# Altium schematic sheet MAIN-POWERSUPPLY.SchDoc
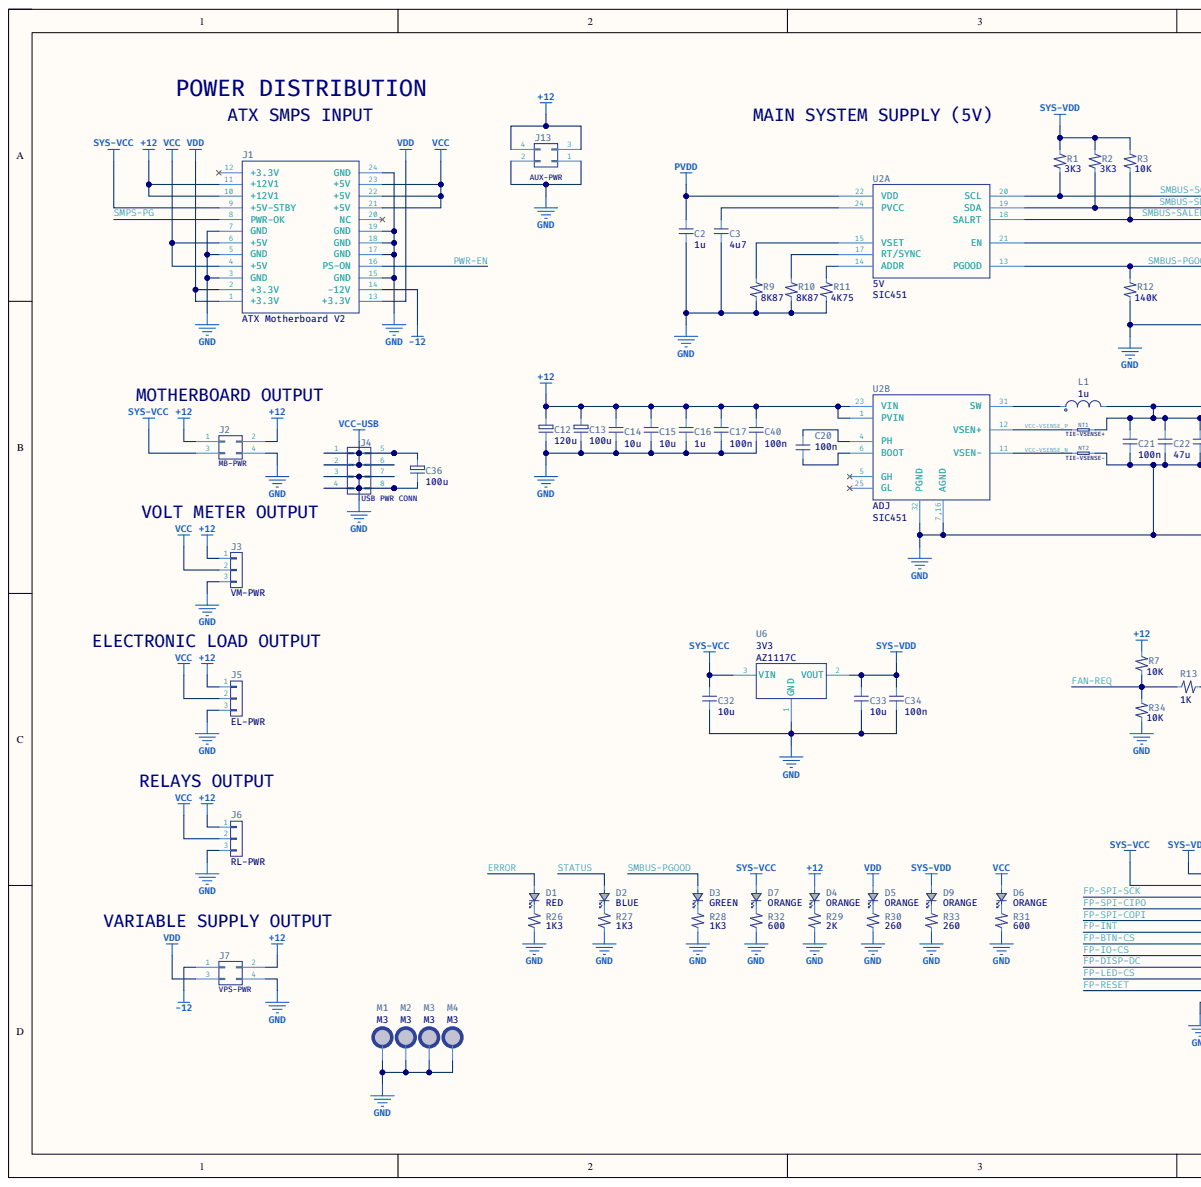
[diagram p0: MAIN-POWERSUPPLY.SchDoc, left]
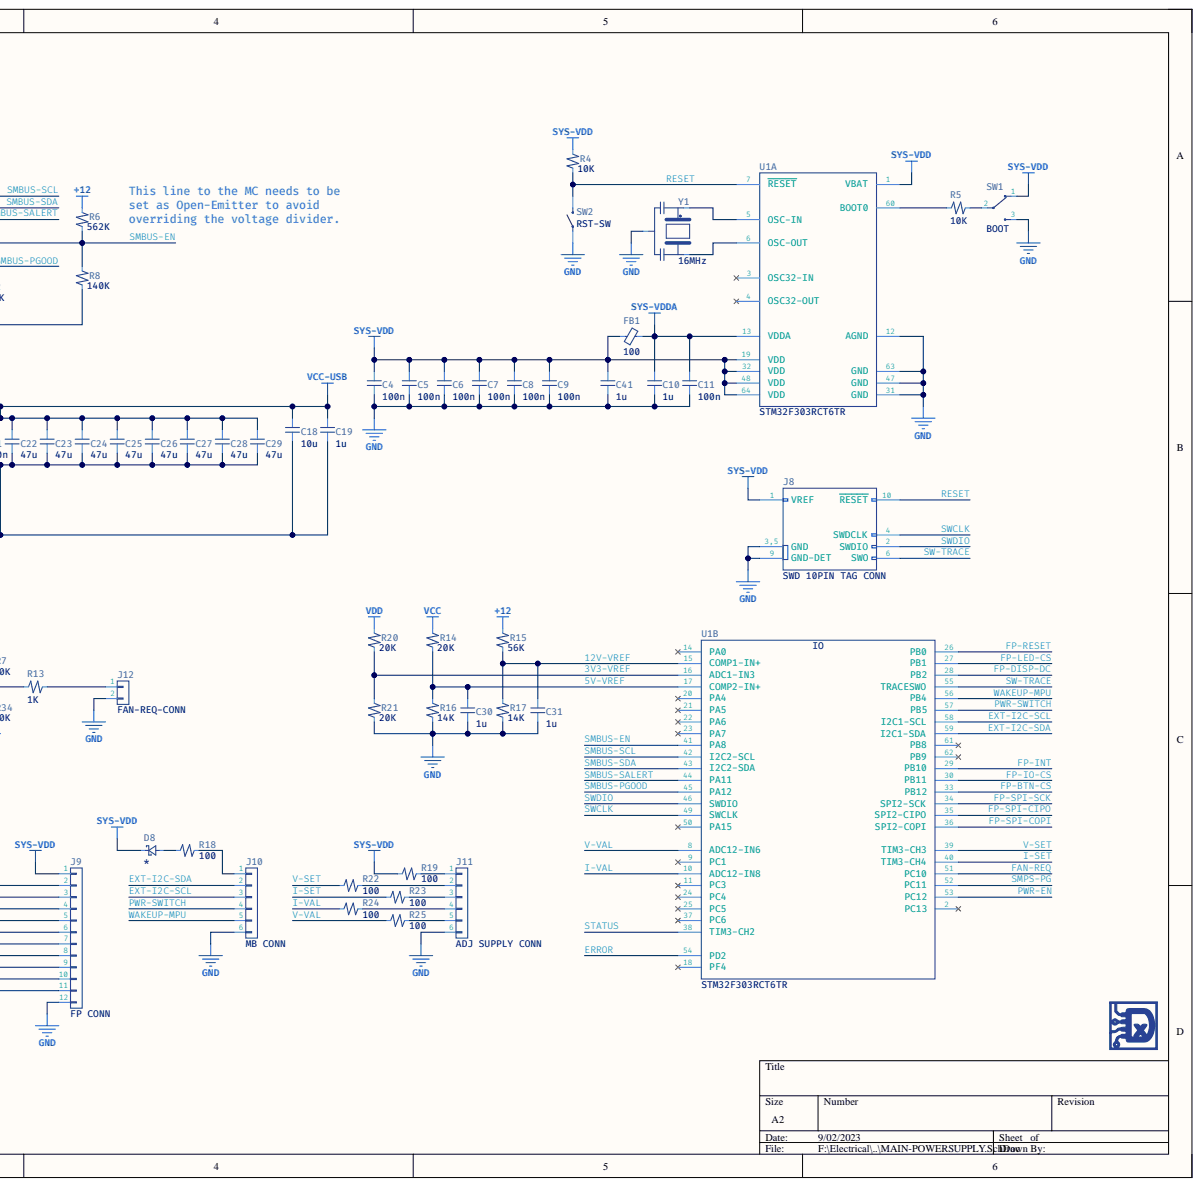
[diagram p1: MAIN-POWERSUPPLY.SchDoc, right]

## Parts
C2  value 1u  [CAP-0805]  (hidden comment: Generic Capacitor)
C3  value 4u7  [CAP-0805]  (hidden comment: Generic Capacitor)
C4  value 100n  [CAP-0402]  (hidden comment: Generic Capacitor)
C5  value 100n  [CAP-0402]  (hidden comment: Generic Capacitor)
C6  value 100n  [CAP-0402]  (hidden comment: Generic Capacitor)
C7  value 100n  [CAP-0402]  (hidden comment: Generic Capacitor)
C8  value 100n  [CAP-0402]  (hidden comment: Generic Capacitor)
C9  value 100n  [CAP-0402]  (hidden comment: Generic Capacitor)
C10  value 1u  [CAP-0603]  (hidden comment: Generic Capacitor)
C11  value 100n  [CAP-0402]  (hidden comment: Generic Capacitor)
C12  value 120u  [CAP-EL-6.3x7.7]  (hidden comment: General Polarized Capacitor)
C13  value 100u  [CAP-EL-6.3x7.7]  (hidden comment: General Polarized Capacitor)
C14  value 10u  [CAP-1210]  (hidden comment: Generic Capacitor)
C15  value 10u  [CAP-1210]  (hidden comment: Generic Capacitor)
C16  value 1u  [CAP-0805]  (hidden comment: Generic Capacitor)
C17  value 100n  [CAP-0402]  (hidden comment: Generic Capacitor)
C18  value 10u  [CAP-1210]  (hidden comment: Generic Capacitor)
C19  value 1u  [CAP-1210]  (hidden comment: Generic Capacitor)
C20  value 100n  [CAP-0201]  (hidden comment: Generic Capacitor)
C21  value 100n  [CAP-0201]  (hidden comment: Generic Capacitor)
C22  value 47u  [CAP-1210]  (hidden comment: Generic Capacitor)
C23  value 47u  [CAP-1210]  (hidden comment: Generic Capacitor)
C24  value 47u  [CAP-1210]  (hidden comment: Generic Capacitor)
C25  value 47u  [CAP-1210]  (hidden comment: Generic Capacitor)
C26  value 47u  [CAP-1210]  (hidden comment: Generic Capacitor)
C27  value 47u  [CAP-1210]  (hidden comment: Generic Capacitor)
C28  value 47u  [CAP-1210]  (hidden comment: Generic Capacitor)
C29  value 47u  [CAP-1210]  (hidden comment: Generic Capacitor)
C30  value 1u  [CAP-0603]  (hidden comment: Generic Capacitor)
C31  value 1u  [CAP-0603]  (hidden comment: Generic Capacitor)
C32  value 10u  [CAP-1210]  (hidden comment: Generic Capacitor)
C33  value 10u  [CAP-1210]  (hidden comment: Generic Capacitor)
C34  value 100n  [CAP-0402]  (hidden comment: Generic Capacitor)
C36  value 100u  [CAP-EL-6.3x7.7]  (hidden comment: General Polarized Capacitor)
C40  value 100n  [CAP-0402]  (hidden comment: Generic Capacitor)
C41  value 1u  [CAP-0603]  (hidden comment: Generic Capacitor)
D1  Color: RED  [LED-0805]  (hidden comment: General LED)
D2  Color: BLUE  [LED-0805]  (hidden comment: General LED)
D3  Color: GREEN  [LED-0805]  (hidden comment: General LED)
D4  Color: ORANGE  [LED-0805]  (hidden comment: General LED)
D5  Color: ORANGE  [LED-0805]  (hidden comment: General LED)
D6  Color: ORANGE  [LED-0805]  (hidden comment: General LED)
D7  Color: ORANGE  [LED-0805]  (hidden comment: General LED)
D8  General Schottky Doide  [D_SOD-323]  (value not drawn)
D9  Color: ORANGE  [LED-0805]  (hidden comment: General LED)
FB1  value 100  [IND-0603]  (hidden comment: Generic Ferrite Bead)
J1  value ATX Motherboard V2  [Molex_Mini-Fit_Jr_24P_39288240]  (hidden comment: ATX SMPS PWR INPUT CONN)
J2  value MB-PWR  (hidden comment: MOTHERBOARD PWR CONN)
J3  value VM-PWR  [JST_XH_B3B-XH-A_1x03_P2.50mm_Vertical]  (hidden comment: VOLT METER PWR CONN)
J4  value USB PWR CONN  (hidden comment: USB HUB PWR CONN)
J5  value EL-PWR  [JST_XH_B3B-XH-A_1x03_P2.50mm_Vertical]  (hidden comment: ELOAD PWR CONN)
J6  value RL-PWR  [JST_XH_B3B-XH-A_1x03_P2.50mm_Vertical]  (hidden comment: RELAYS PWR CONN)
J7  value VPS-PWR  (hidden comment: ADJ SUPPLY PWR CONN)
J8  value SWD 10PIN TAG CONN  [Tag-Connect_TC2050-IDC-FP_2x05_P1.27mm_Vertical]  (hidden comment: SWD-TAG-10)
J9  value FP CONN  [JST_XH_S12B-XH-A_1x12_P2.50mm_Horizontal]  (hidden comment: FRONT PANEL CONN)
J10  value MB CONN  [JST_XH_B6B-XH-A_1x06_P2.50mm_Vertical]  (hidden comment: MOTHERBOARD SIG CONN)
J11  ADJ SUPPLY CONN  [JST_XH_B6B-XH-A_1x06_P2.50mm_Vertical]
J12  value FAN-REQ-CONN  [JST_XH_B2B-XH-A_1x02_P2.50mm_Vertical]  (hidden comment: FAN REQUEST CONN)
J13  value AUX-PWR  (hidden comment: AUXILIARY PWR IN CONN)
L1  value 1u  [ETQ-P4M1R0KVC]  (hidden comment: L-PWR)
M1  value M3  [PTH-MOUNT-M3-VIA]  (hidden comment: Plated Mounting Hole)
M2  value M3  [PTH-MOUNT-M3-VIA]  (hidden comment: Plated Mounting Hole)
M3  value M3  [PTH-MOUNT-M3-VIA]  (hidden comment: Plated Mounting Hole)
M4  value M3  [PTH-MOUNT-M3-VIA]  (hidden comment: Plated Mounting Hole)
NT1  value TIE-VSENSE+  [Net-Tie-0.15mm]  (hidden comment: NET-TIE)
NT2  value TIE-VSENSE-  [Net-Tie-0.15mm]  (hidden comment: NET-TIE)
R1  value 3K3  [RES-0402]  (hidden comment: General Resistor)
R2  value 3K3  [RES-0402]  (hidden comment: General Resistor)
R3  value 10K  [RES-0402]  (hidden comment: General Resistor)
R4  value 10K  [RES-0402]  (hidden comment: General Resistor)
R5  value 10K  [RES-0402]  (hidden comment: General Resistor)
R6  value 562K  [RES-0402]  (hidden comment: General Resistor)
R7  value 10K  [RES-0402]  (hidden comment: General Resistor)
R8  value 140K  [RES-0402]  (hidden comment: General Resistor)
R9  value 8K87  [RES-0402]  (hidden comment: General Resistor)
R10  value 8K87  [RES-0402]  (hidden comment: General Resistor)
R11  value 4K75  [RES-0402]  (hidden comment: General Resistor)
R12  value 140K  [RES-0402]  (hidden comment: General Resistor)
R13  value 1K  [RES-0402]  (hidden comment: General Resistor)
R14  value 20K  [RES-0402]  (hidden comment: General Resistor)
R15  value 56K  [RES-0402]  (hidden comment: General Resistor)
R16  value 14K  [RES-0402]  (hidden comment: General Resistor)
R17  value 14K  [RES-0402]  (hidden comment: General Resistor)
R18  value 100  [RES-0402]  (hidden comment: General Resistor)
R19  value 100  [RES-0402]  (hidden comment: General Resistor)
R20  value 20K  [RES-0402]  (hidden comment: General Resistor)
R21  value 20K  [RES-0402]  (hidden comment: General Resistor)
R22  value 100  [RES-0402]  (hidden comment: General Resistor)
R23  value 100  [RES-0402]  (hidden comment: General Resistor)
R24  value 100  [RES-0402]  (hidden comment: General Resistor)
R25  value 100  [RES-0402]  (hidden comment: General Resistor)
R26  value 1K3  [RES-0402]  (hidden comment: General Resistor)
R27  value 1K3  [RES-0402]  (hidden comment: General Resistor)
R28  value 1K3  [RES-0402]  (hidden comment: General Resistor)
R29  value 2K  [RES-0402]  (hidden comment: General Resistor)
R30  value 260  [RES-0402]  (hidden comment: General Resistor)
R31  value 600  [RES-0402]  (hidden comment: General Resistor)
R32  value 600  [RES-0402]  (hidden comment: General Resistor)
R33  value 260  [RES-0402]  (hidden comment: General Resistor)
R34  value 10K  [RES-0402]  (hidden comment: General Resistor)
SW1  value BOOT  [PCM12SMTR]  (hidden comment: Generic Toogle Switch STDP)
SW2  value RST-SW  [TL3365AF180QG]  (hidden comment: Generic STSP Switch)
U1  value STM32F303RCT6TR  [LQFP-64_10x10mm_P0.5mm]  (hidden comment: IC MCU 32BIT 256KB FLASH)  (parts A,B)
U2  value SIC451  Output: 5V  [SIC451ED-T1-GE3]  (hidden comment: IC SW REG MICROBUCK DC/DC CONVERTER 25 A)  (parts A,B)
U6  value AZ1117C  VOUT: 3V3  [SOT-223-3_TabPin2]  (hidden comment: IC REG LINEAR 3.3V 1A)
Y1  value 16MHz  [RESO-3-3x1.1mm]  (hidden comment: General 3P Resonator)

## Nets
-12: J1.14(-12V) J7.1
GND: C2.2 C3.2 C4.2 C5.2 C6.2 C7.2 C8.2 C9.2 C10.2 C11.2 C12.2 C13.2 C14.2 C15.2 C16.2 C17.2 C18.2 C19.2 C21.2 C22.2 C23.2 C24.2 C25.2 C26.2 C27.2 C28.2 C29.2 C30.2 C31.2 C32.2 C33.2 C34.2 C36.2 C40.2 C41.2 J1.3(GND) J1.5(GND) J1.7(GND) J1.15(GND) J1.17(GND) J1.18(GND) J1.19(GND) J1.24(GND) J2.4 J3.3 J4.3 J4.4 J4.7 J4.8 J5.3 J6.3 J7.4 J8.3,5(GND) J8.9(GND-DET) J9.12 J10.6 J11.6 J12.2 J13.1 J13.2 M1.1 M2.1 M3.1 M4.1 NT2.2 R8.1 R9.1 R10.1 R11.1 R12.1 R16.1 R17.1 R21.1 R26.1 R27.1 R28.1 R29.1 R30.1 R31.1 R32.1 R33.1 R34.1 SW1.3 SW2.1 U1.12(AGND) U1.31(GND) U1.47(GND) U1.63(GND) U2.7,16(AGND) U2.32(PGND) U6.1 Y1.2
PVDD: C2.1 U2.22(VDD)
SYS-VCC: C32.1 D7.2 J1.9(+5V-STBY) J2.3 J9.2 U6.3
SYS-VDD: C4.1 C5.1 C6.1 C7.1 C8.1 C9.1 C33.1 C34.1 C41.1 D8.1 D9.2 FB1.1 J8.1(VREF) J9.1 R1.2 R2.2 R3.2 R4.2 R19.2 SW1.1 U1.1(VBAT) U1.19(VDD) U1.32(VDD) U1.48(VDD) U1.64(VDD) U6.2
SYS-VDDA: C10.1 C11.1 FB1.2 U1.13(VDDA)
VCC: D6.2 J1.4(+5V) J1.6(+5V) J1.21(+5V) J1.22(+5V) J1.23(+5V) J3.2 J5.2 J6.2 R14.2
VCC-USB: C18.1 C19.1 C21.1 C22.1 C23.1 C24.1 C25.1 C26.1 C27.1 C28.1 C29.1 C36.1 J4.1 J4.2 J4.5 J4.6 L1.2 NT1.2
VDD: D5.2 J1.1(+3.3V) J1.2(+3.3V) J1.13(+3.3V) J7.3 R20.2
3V3-VREF: R20.1 R21.2 U1.16(ADC1-IN3)
5V-VREF: C30.1 R14.1 R16.2 U1.17(COMP2-IN+)
12V-VREF: C12.1 C13.1 C14.1 C15.1 C16.1 C17.1 C31.1 C40.1 D4.2 J1.10(+12V1) J1.11(+12V1) J2.1 J2.2 J3.1 J5.1 J6.1 J7.2 J13.3 J13.4 R6.2 R7.2 R15.1 R15.2 R17.2 U1.15(COMP1-IN+) U2.1(PVIN) U2.23(VIN)
ERROR: D1.2 U1.54(PD2)
EXT-I2C-SCL: J10.3 U1.58(I2C1-SCL)
EXT-I2C-SDA: J10.2 U1.59(I2C1-SDA)
FAN-REQ: R7.1 R13.1 R34.2 U1.51(PC10)
FP-BTN-CS: J9.7 U1.33(PB12)
FP-DISP-DC: J9.9 U1.28(PB2)
FP-INT: J9.6 U1.29(PB10)
FP-IO-CS: J9.8 U1.30(PB11)
FP-LED-CS: J9.10 U1.27(PB1)
FP-RESET: J9.11 U1.26(PB0)
FP-SPI-CIPO: J9.4 U1.35(SPI2-CIPO)
FP-SPI-COPI: J9.5 U1.36(SPI2-COPI)
FP-SPI-SCK: J9.3 U1.34(SPI2-SCK)
I-SET: R23.2 U1.40(TIM3-CH4)
I-VAL: R24.2 U1.10(ADC12-IN8)
PWR-EN: J1.16(PS-ON) U1.53(PC12)
PWR-SWITCH: J10.4 U1.57(PB5)
RESET: J8.10(RESET) R4.1 SW2.2 U1.7(RESET)
SMBUS-EN: R6.1 R8.2 U1.41(PA8) U2.21(EN)
SMBUS-PGOOD: D3.2 R12.2 U1.45(PA12) U2.13(PGOOD)
SMBUS-SALERT: R3.1 U1.44(PA11) U2.18(SALRT)
SMBUS-SCL: R1.1 U1.42(I2C2-SCL) U2.20(SCL)
SMBUS-SDA: R2.1 U1.43(I2C2-SDA) U2.19(SDA)
SMPS-PG: J1.8(PWR-OK) U1.52(PC11)
STATUS: D2.2 U1.38(TIM3-CH2)
SW-TRACE: J8.6(SWO) U1.55(TRACESWO)
SWCLK: J8.4(SWDCLK) U1.49(SWCLK)
SWDIO: J8.2(SWDIO) U1.46(SWDIO)
V-SET: R22.2 U1.39(TIM3-CH3)
V-VAL: R25.2 U1.8(ADC12-IN6)
VCC-VSENSE_N: NT2.1 U2.11(VSEN-)
VCC-VSENSE_P: NT1.1 U2.12(VSEN+)
WAKEUP-MPU: J10.5 U1.56(PB4)
NetC3_1: C3.1 U2.24(PVCC)
NetC20_1: C20.1 U2.4(PH)
NetC20_2: C20.2 U2.6(BOOT)
NetD1_1: D1.1 R26.2
NetD2_1: D2.1 R27.2
NetD3_1: D3.1 R28.2
NetD4_1: D4.1 R29.2
NetD5_1: D5.1 R30.2
NetD6_1: D6.1 R31.2
NetD7_1: D7.1 R32.2
NetD8_2: D8.2 R18.2
NetD9_1: D9.1 R33.2
NetJ10_1: J10.1 R18.1
NetJ11_1: J11.1 R19.1
NetJ11_2: J11.2 R22.1
NetJ11_3: J11.3 R23.1
NetJ11_4: J11.4 R24.1
NetJ11_5: J11.5 R25.1
NetJ12_1: J12.1 R13.2
NetL1_1: L1.1 U2.31
NetR5_1: R5.1 SW1.2
NetR5_2: R5.2 U1.60(BOOT0)
NetR9_2: R9.2 U2.15(VSET)
NetR10_2: R10.2 U2.17(RT/SYNC)
NetR11_2: R11.2 U2.14(ADDR)
NetU1_5: U1.5(OSC-IN) Y1.1
NetU1_6: U1.6(OSC-OUT) Y1.3

Not connected: J1 12(+3.3V) 20(NC); U1 2(PC13) 3(OSC32-IN) 4(OSC32-OUT) 9(PC1) 11(PC3) 14(PA0) 18(PF4) 20(PA4) 21(PA5) 22(PA6) 23(PA7) 24(PC4) 25(PC5) 37(PC6) 50(PA15) 61(PB8) 62(PB9); U2 5(GH) 25(GL)

## Library data of the parts
C2-C11 C14-C34 C40 C41 = C: General un-polarized capacitor; Tolerance: 5%; Component Kind: Standard; Library Name: Daxxn_Device.SchLib
  C2: Supplier 1: Digi-Key; Supplier 2: Digi-Key; Supplier 3: Digi-Key; Supplier 4: Mouser; Supplier Part Number 1: 1276-1275-1-ND; Supplier Part Number 2: 1276-1275-6-ND; Supplier Part Number 3: 1276-1275-2-ND; Supplier Part Number 4: 187-CL21B105KPFNNNE
  C3: Supplier 1: Digi-Key; Supplier 2: Digi-Key; Supplier 3: Digi-Key; Supplier 4: Mouser; Supplier Part Number 1: 1276-6722-1-ND; Supplier Part Number 2: 1276-6722-6-ND; Supplier Part Number 3: 1276-6722-2-ND; Supplier Part Number 4: 187-CL21A475KLCLQNC
  C4-C9 C11 C17 C34 C40: Supplier 1: Digi-Key; Supplier 2: Digi-Key; Supplier 3: Digi-Key; Supplier 4: Mouser; Supplier Part Number 1: 1276-6720-1-ND; Supplier Part Number 2: 1276-6720-6-ND; Supplier Part Number 3: 1276-6720-2-ND; Supplier Part Number 4: 187-CL05B104KA5NNNC
  C10 C30 C31 C41: Supplier 1: Digi-Key; Supplier 2: Digi-Key; Supplier 3: Digi-Key; Supplier 4: Mouser; Supplier Part Number 1: 1276-1102-1-ND; Supplier Part Number 2: 1276-1102-6-ND; Supplier Part Number 3: 1276-1102-2-ND; Supplier Part Number 4: 187-CL10A105KA8NNNC
  C14 C15 C32 C33: Supplier 1: Digi-Key; Supplier 2: Digi-Key; Supplier 3: Digi-Key; Supplier 4: Mouser; Supplier Part Number 1: 1276-1854-1-ND; Supplier Part Number 2: 1276-1854-6-ND; Supplier Part Number 3: 1276-1854-2-ND; Supplier Part Number 4: 187-CL32A106KAULNNE
  C16: Supplier 1: Digi-Key; Supplier 2: Digi-Key; Supplier 3: Digi-Key; Supplier 4: Mouser; Supplier Part Number 1: 1276-3010-1-ND; Supplier Part Number 2: 1276-3010-6-ND; Supplier Part Number 3: 1276-3010-2-ND; Supplier Part Number 4: 187-CL21F105ZBFNNNE
  C22-C29: Supplier 1: Avnet; Supplier 2: Digi-Key; Supplier 3: Digi-Key; Supplier 4: Digi-Key; Supplier 5: Mouser; Supplier Part Number 1: CL32A476KOJNNNE; Supplier Part Number 2: 1276-3376-1-ND; Supplier Part Number 3: 1276-3376-6-ND; Supplier Part Number 4: 1276-3376-2-ND; Supplier Part Number 5: 187-CL32A476KOJNNNE
C12 C13 C36 = C-POL: Tolerance: 10%; Component Kind: Standard; Description: General Polarized Capacitor; Library Name: Daxxn_Device.SchLib
D1-D7 D9 = LED: Component Kind: Standard; ComponentLink1Description: Datasheet; ComponentLink2Description: LocalDatasheet; Description: General LED; Library Name: Daxxn_Device.SchLib
D8 = D-SK: Component Kind: Standard; ComponentLink1Description: Datasheet; ComponentLink2Description: LocalDatasheet; Description: General Schottky Doide; Library Name: Daxxn_Device.SchLib
FB1 = FerriteBead: Component Kind: Standard; Description: Generic Ferrite Bead; Supplier 1: Digi-Key; Supplier 2: Digi-Key; Supplier 3: Digi-Key; Supplier 4: Mouser; Supplier Part Number 1: 445-MPZ1608S101ATAH0CT-ND; Supplier Part Number 2: 445-MPZ1608S101ATAH0DKR-ND; Supplier Part Number 3: 445-MPZ1608S101ATAH0TR-ND; Supplier Part Number 4: 810-MPZ1608S101ATAH0; Library Name: Daxxn_Device.SchLib
J1 = ATX-MB-V2-24P: Component Kind: Standard; ComponentLink1Description: Datasheet; ComponentLink2Description: LocalDatasheet; Library Name: Connector.SchLib; Supplier 1: Mouser; Supplier 2: Digi-Key; Supplier Part Number 1: 538-39-29-9243; Supplier Part Number 2: 23-0039299243-ND
J2 J7 J13 = Conn_02x02_Odd_Even: Component Kind: Standard; Library Name: Connector_Generic.SchLib; Supplier 1: Mouser; Supplier 2: Newark; Supplier 3: Digi-Key; Supplier Part Number 1: 538-39-29-3046; Supplier Part Number 2: 34R5717; Supplier Part Number 3: WM3844-ND
J3 J5 J6 = Conn_01x03: Component Kind: Standard; ComponentLink1Description: Datasheet; ComponentLink2Description: LocalDatasheet; Library Name: Connector_Generic.SchLib; Supplier 1: Digi-Key; Supplier 2: Arrow Electronics; Supplier 3: Newark; Supplier 4: Future Electronics; Supplier 5: Farnell; Supplier 6: Arrow Electronics; Supplier 7: Future Electronics; Supplier Part Number 1: 455-2248-ND; Supplier Part Number 2: B3B-XH-A(LF)(SN); Supplier Part Number 3: 73M9431; Supplier Part Number 4: 8262735; Supplier Part Number 5: 1516277; Supplier Part Number 6: B3B-XH-AM(LF)(SN); Supplier Part Number 7: 8302473
J4 = Conn_02x04_Top_Bottom: Connector for sending VBUS power to the USB Hub.; Component Kind: Standard; Library Name: Connector_Generic.SchLib; Supplier 1: Mouser; Supplier 2: Newark; Supplier 3: Digi-Key; Supplier Part Number 1: 538-39-28-1083; Supplier Part Number 2: 26AC7228; Supplier Part Number 3: WM3803-ND
J9 = Conn_01x12: Component Kind: Standard; ComponentLink1Description: Datasheet; ComponentLink2Description: LocalDatasheet; Library Name: Connector_Generic.SchLib; Supplier 1: Digi-Key; Supplier Part Number 1: 455-B12B-XH-A-ND
J10 J11 = Conn_01x06: Component Kind: Standard; ComponentLink1Description: Datasheet; ComponentLink2Description: LocalDatasheet; Library Name: Connector_Generic.SchLib; Supplier 1: Digi-Key; Supplier Part Number 1: 455-B6B-XH-A-ND
  J11: Value: ADJ SUPPLY CONN
J12 = Conn_01x02: Component Kind: Standard; ComponentLink1Description: Datasheet; ComponentLink2Description: LocalDatasheet; Library Name: Connector_Generic.SchLib; Supplier 1: Digi-Key; Supplier Part Number 1: 455-B2B-XH-A-ND
L1 = L-PWR: Tolerance: 5%; Component Kind: Standard; ComponentLink1Description: Datasheet; ComponentLink2Description: LocalDatasheet; Supplier 1: Mouser; Supplier 2: Newark; Supplier 3: Digi-Key; Supplier 4: Digi-Key; Supplier 5: Digi-Key; Supplier Part Number 1: 667-ETQ-P4M1R0KVC; Supplier Part Number 2: 82Y5420; Supplier Part Number 3: P19789CT-ND; Supplier Part Number 4: P19789DKR-ND; Supplier Part Number 5: P19789TR-ND; Library Name: Daxxn_Device.SchLib
M1-M4 = MountingHole-PTH: Generic Plated Mounting Through Hole; Component Kind: Standard; ComponentLink1Description: Datasheet; ComponentLink2Description: LocalDatasheet; Library Name: Daxxn_Device.SchLib
R1-R34 = R: General purpose resistor; Tolerance: 1%; Component Kind: Standard; Library Name: Daxxn_Device.SchLib
  R1 R2: Supplier 1: Digi-Key; Supplier 2: Digi-Key; Supplier 3: Digi-Key; Supplier 4: Mouser; Supplier Part Number 1: 1292-WR04X3301FTLCT-ND; Supplier Part Number 2: 1292-WR04X3301FTLDKR-ND; Supplier Part Number 3: 1292-WR04X3301FTLTR-ND; Supplier Part Number 4: 791-WR04X3301FTL
  R3-R5 R7 R13 R34: Supplier 1: Newark; Supplier 2: Digi-Key; Supplier 3: Digi-Key; Supplier 4: Digi-Key; Supplier 5: Mouser; Supplier Part Number 1: 98K7445; Supplier Part Number 2: 311-1.0KJRCT-ND; Supplier Part Number 3: 311-1.0KJRDKR-ND; Supplier Part Number 4: 311-1.0KJRTR-ND; Supplier Part Number 5: 603-RC0402JR-071KL
  R6: Supplier 1: Newark; Supplier 2: Newark; Supplier 3: Digi-Key; Supplier 4: Digi-Key; Supplier 5: Digi-Key; Supplier 6: Mouser; Supplier Part Number 1: 68AK6794; Supplier Part Number 2: 49AK1553; Supplier Part Number 3: 311-562KLRCT-ND; Supplier Part Number 4: 311-562KLRDKR-ND; Supplier Part Number 5: 311-562KLRTR-ND; Supplier Part Number 6: 603-RC0402FR-07562KL
  R8 R12: Supplier 1: Newark; Supplier 2: Newark; Supplier 3: Digi-Key; Supplier 4: Digi-Key; Supplier 5: Digi-Key; Supplier 6: Mouser; Supplier Part Number 1: 68AK6524; Supplier Part Number 2: 49AK1263; Supplier Part Number 3: YAG2978CT-ND; Supplier Part Number 4: YAG2978DKR-ND; Supplier Part Number 5: YAG2978TR-ND; Supplier Part Number 6: 603-RC0402FR-07140KL
  R9 R10: Supplier 1: Newark; Supplier 2: Digi-Key; Supplier 3: Digi-Key; Supplier 4: Digi-Key; Supplier 5: Mouser; Supplier Part Number 1: 49AK1629; Supplier Part Number 2: 311-8.87KLRCT-ND; Supplier Part Number 3: 311-8.87KLRDKR-ND; Supplier Part Number 4: 311-8.87KLRTR-ND; Supplier Part Number 5: 603-RC0402FR-078K87L
  R11: Supplier 1: Newark; Supplier 2: Digi-Key; Supplier 3: Digi-Key; Supplier 4: Digi-Key; Supplier 5: Mouser; Supplier Part Number 1: 32AC2496; Supplier Part Number 2: RMCF0402FT4K70CT-ND; Supplier Part Number 3: RMCF0402FT4K70DKR-ND; Supplier Part Number 4: RMCF0402FT4K70TR-ND; Supplier Part Number 5: 708-RMCF0402FT4K70
  R14 R20 R21: Supplier 1: Newark; Supplier 2: Digi-Key; Supplier 3: Digi-Key; Supplier 4: Digi-Key; Supplier 5: Mouser; Supplier Part Number 1: 82AH7900; Supplier Part Number 2: 311-20.0KLRCT-ND; Supplier Part Number 3: 311-20.0KLRDKR-ND; Supplier Part Number 4: 311-20.0KLRTR-ND; Supplier Part Number 5: 603-RC0402FR-0720KL
  R15: Supplier 1: Newark; Supplier 2: Digi-Key; Supplier 3: Digi-Key; Supplier 4: Digi-Key; Supplier 5: Mouser; Supplier Part Number 1: 73AC8916; Supplier Part Number 2: A129648CT-ND; Supplier Part Number 3: A129648DKR-ND; Supplier Part Number 4: A129648TR-ND; Supplier Part Number 5: 279-CRGCQ0402F56K
  R16 R17: Supplier 1: Newark; Supplier 2: Newark; Supplier 3: Newark; Supplier 4: Digi-Key; Supplier 5: Digi-Key; Supplier 6: Digi-Key; Supplier 7: Mouser; Supplier Part Number 1: 49AK1260; Supplier Part Number 2: 68AK6519; Supplier Part Number 3: 82AH7877; Supplier Part Number 4: 311-14.0KLRCT-ND; Supplier Part Number 5: 311-14.0KLRDKR-ND; Supplier Part Number 6: 311-14.0KLRTR-ND; Supplier Part Number 7: 603-RC0402FR-0714KL
  R18 R19 R22-R25: Supplier 1: Newark; Supplier 2: Newark; Supplier 3: Digi-Key; Supplier 4: Digi-Key; Supplier 5: Digi-Key; Supplier 6: Mouser; Supplier Part Number 1: 98K7444; Supplier Part Number 2: 67R9968; Supplier Part Number 3: 311-100JRCT-ND; Supplier Part Number 4: 311-100JRDKR-ND; Supplier Part Number 5: 311-100JRTR-ND; Supplier Part Number 6: 603-RC0402JR-07100RL
  R26-R30 R32 R33: Supplier 1: Digi-Key; Supplier 2: Digi-Key; Supplier 3: Mouser; Supplier Part Number 1: 1292-WR04X221JTLCT-ND; Supplier Part Number 2: 1292-WR04X221JTLDKR-ND; Supplier Part Number 3: 791-WR04X221JTL
  R31: Supplier 1: Digi-Key; Supplier 2: Digi-Key; Supplier 3: Digi-Key; Supplier 4: Mouser; Supplier Part Number 1: 1292-WR04X3300FTLCT-ND; Supplier Part Number 2: 1292-WR04X3300FTLDKR-ND; Supplier Part Number 3: 1292-WR04X3300FTLTR-ND; Supplier Part Number 4: 791-WR04X3300FTL
SW1 = Switch-STDP: Component Kind: Standard; Description: Generic Toogle Switch STDP; Supplier 1: Newark; Supplier 2: Digi-Key; Supplier 3: Digi-Key; Supplier 4: Digi-Key; Supplier 5: Mouser; Supplier Part Number 1: 35AH4615; Supplier Part Number 2: 401-2016-1-ND; Supplier Part Number 3: 401-2016-6-ND; Supplier Part Number 4: 401-2016-2-ND; Supplier Part Number 5: 611-PCM12SMTR; Library Name: Daxxn_Device.SchLib
SW2 = Switch-STSP: Component Kind: Standard; Description: Generic STSP Switch; Supplier 1: Newark; Supplier 2: Digi-Key; Supplier 3: Digi-Key; Supplier 4: Digi-Key; Supplier 5: Mouser; Supplier Part Number 1: 84Y2787; Supplier Part Number 2: EG5384CT-ND; Supplier Part Number 3: EG5384DKR-ND; Supplier Part Number 4: EG5384TR-ND; Supplier Part Number 5: 612-TL3365AF180QG; Library Name: Daxxn_Device.SchLib
U1 = STM32F303RCT6TR: ARM Cortex-M4 STM32F3 Microcontroller IC 32-Bit Single-Core 72MHz 256KB (256K x 8) FLASH LQFP-64; Component Kind: Standard; ComponentLink1Description: Datasheet; ComponentLink1URL: https://www.st.com/content/ccc/resource/technical/document/datasheet/f2/1f/e1/41/ef/59/4d/50/DM00058181.pdf/files/DM00058181.pdf/jcr:content/translations/en.DM00058181.pdf; ComponentLink2Description: LocalDatasheet; Supplier 1: Digi-Key; Supplier 2: Digi-Key; Supplier 3: Digi-Key; Supplier 4: Arrow Electronics; Supplier 5: Mouser; Supplier 6: Avnet; Supplier Part Number 1: 497-19002-6-ND; Supplier Part Number 2: 497-19002-1-ND; Supplier Part Number 3: 497-19002-2-ND; Supplier Part Number 4: STM32F303RCT6TR; Supplier Part Number 5: 511-STM32F303RCT6TR; Supplier Part Number 6: STM32F303RCT6TR; ComponentLink2URL: F:\Electrical\Datasheets\STM32F303xC.pdf; Library Name: Daxxn_MicroControllers.SchLib
U2 = SIC451ED-T1-GE3: Buck Switching Regulator IC Positive Adjustable 0.3V 25A PowerWFQFN-34; Component Kind: Standard; ComponentLink1Description: LocalDatasheet; ComponentLink2Description: Datasheet; ComponentLink2URL: https://www.vishay.com/docs/77863/sic450_sic451_sic453.pdf; Supplier 1: Digi-Key; Supplier 2: Mouser; Supplier Part Number 1: 742-SIC451ED-T1-GE3CT-ND; Supplier Part Number 2: 78-SIC451ED-T1-GE3; ComponentLink1URL: F:\Electrical\Datasheets\SIC450.pdf; Library Name: Daxxn_Regulators.SchLib
U6 = AZ1117C: Linear Voltage Regulator IC Positive Fixed 1 Output 1A; Component Kind: Standard; ComponentLink1Description: Datasheet; ComponentLink1URL: https://www.diodes.com/assets/Datasheets/AZ1117C.pdf; ComponentLink2Description: LocalDatasheet; Supplier 1: Digi-Key; Supplier 2: Digi-Key; Supplier 3: Mouser; Supplier 4: Newark; Supplier Part Number 1: 31-AZ1117CH2-3.3TRG1CT-ND; Supplier Part Number 2: 31-AZ1117CH2-3.3TRG1DKR-ND; Supplier Part Number 3: 621-AZ1117CH2-33TRG1; Supplier Part Number 4: 76AH4966; ComponentLink2URL: F:\Electrical\Datasheets\AZ1117C.pdf; Library Name: Daxxn_Regulators.SchLib
Y1 = Resonator: General 3-Pin Resonator; Tolerance: 15ppm; Component Kind: Standard; ComponentLink1Description: Datasheet; ComponentLink2Description: LocalDatasheet; Supplier 1: Newark; Supplier 2: Newark; Supplier 3: Digi-Key; Supplier 4: Digi-Key; Supplier 5: Digi-Key; Supplier 6: Mouser; Supplier Part Number 1: 62AK5106; Supplier Part Number 2: 62AK5105; Supplier Part Number 3: 490-17948-1-ND; Supplier Part Number 4: 490-17948-6-ND; Supplier Part Number 5: 490-17948-2-ND; Supplier Part Number 6: 81-CSTNE16M0V530000R; Library Name: Daxxn_Device.SchLib
J8 = SWD-TAG-10: Component Kind: Standard; ComponentLink1Description: Datasheet; ComponentLink2Description: LocalDatasheet; Library Name: Daxxn_Connectors.SchLib
NT1 NT2 = NET-TIE: Component Kind: Net Tie; ComponentLink1Description: Datasheet; ComponentLink2Description: LocalDatasheet; Library Name: Daxxn_Other.SchLib
